# Altium schematic sheet RS232.SchDoc(RS232)
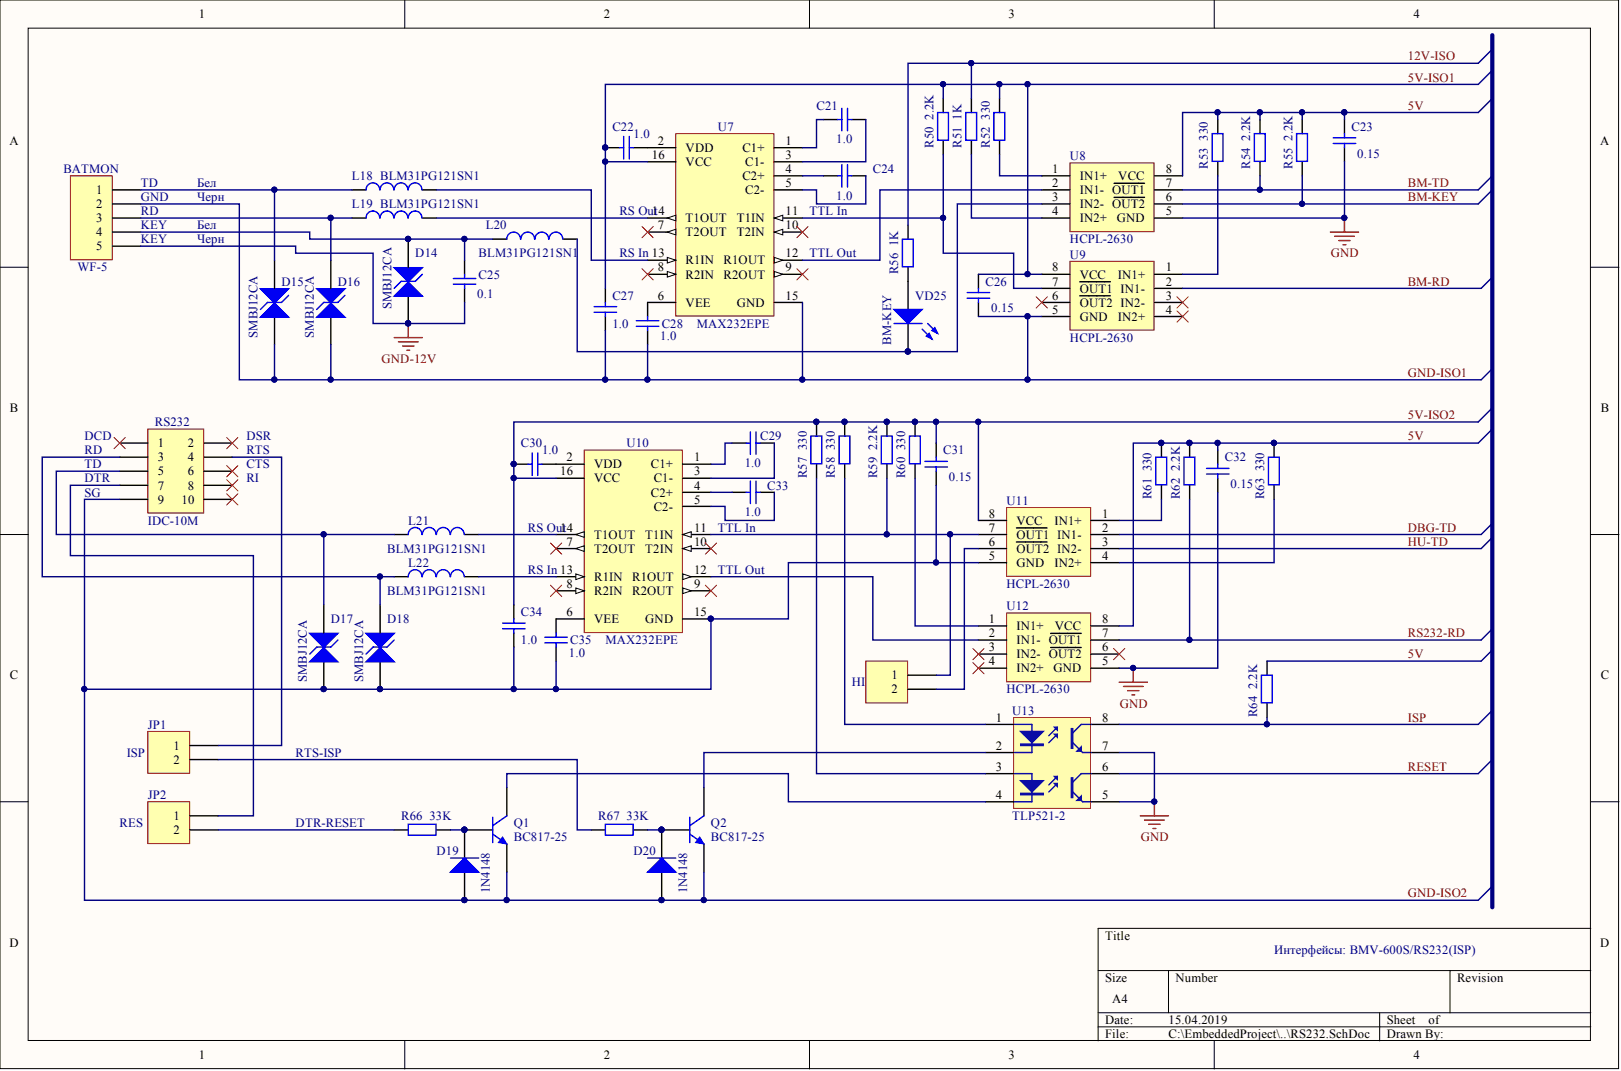
[diagram p0: RS232.SchDoc]

## Parts
BATMON  value WF-5  [WF-5]  (hidden comment: Header, 5-Pin)
C21  value 1.0  [C1206]  (hidden comment: Cap)
C22  value 1.0  [C1206]  (hidden comment: Cap)
C23  value 0.15  [C1206]  (hidden comment: Cap)
C24  value 1.0  [C1206]  (hidden comment: Cap)
C25  value 0.1  [C1206]  (hidden comment: Cap)
C26  value 0.15  [C1206]  (hidden comment: Cap)
C27  value 1.0  [C1206]  (hidden comment: Cap)
C28  value 1.0  [C1206]  (hidden comment: Cap)
C29  value 1.0  [C1206]  (hidden comment: Cap)
C30  value 1.0  [C1206]  (hidden comment: Cap)
C31  value 0.15  [C1206]  (hidden comment: Cap)
C32  value 0.15  [C1206]  (hidden comment: Cap)
C33  value 1.0  [C1206]  (hidden comment: Cap)
C34  value 1.0  [C1206]  (hidden comment: Cap)
C35  value 1.0  [C1206]  (hidden comment: Cap)
D14  SMBJ12CA  [DO-214AA]
D15  SMBJ12CA  [DO-214AA]
D16  SMBJ12CA  [DO-214AA]
D17  SMBJ12CA  [DO-214AA]
D18  SMBJ12CA  [DO-214AA]
D19  value 1N4148  [D1206]  (hidden comment: High Conductance Fast Diode)
D20  value 1N4148  [D1206]  (hidden comment: High Conductance Fast Diode)
H-I  HI  [HDR1X2]
JP1  ISP  [HDR1X2]
JP2  RES  [HDR1X2]
L18  value BLM31PG121SN1  [L1206]  (hidden comment: Ferrite Chip Beads)
L19  value BLM31PG121SN1  [L1206]  (hidden comment: Ferrite Chip Beads)
L20  value BLM31PG121SN1  [L1206]  (hidden comment: Ferrite Chip Beads)
L21  value BLM31PG121SN1  [L1206]  (hidden comment: Ferrite Chip Beads)
L22  value BLM31PG121SN1  [L1206]  (hidden comment: Ferrite Chip Beads)
Q1  value BC817-25  [SOT-23A_N]  (hidden comment: SOT23 NPN Silicon Planar General Purpose Transistor)
Q2  value BC817-25  [SOT-23A_N]  (hidden comment: SOT23 NPN Silicon Planar General Purpose Transistor)
R50  value 2.2K  [1206]  (hidden comment: Res2)
R51  value 1K  [1206]  (hidden comment: Res2)
R52  value 330  [1206]  (hidden comment: Res2)
R53  value 330  [1206]  (hidden comment: Res2)
R54  value 2.2K  [1206]  (hidden comment: Res2)
R55  value 2.2K  [1206]  (hidden comment: Res2)
R56  value 1K  [1206]  (hidden comment: Res2)
R57  value 330  [1206]  (hidden comment: Res2)
R58  value 330  [1206]  (hidden comment: Res2)
R59  value 2.2K  [1206]  (hidden comment: Res2)
R60  value 330  [1206]  (hidden comment: Res2)
R61  value 330  [1206]  (hidden comment: Res2)
R62  value 2.2K  [1206]  (hidden comment: Res2)
R63  value 330  [1206]  (hidden comment: Res2)
R64  value 2.2K  [1206]  (hidden comment: Res2)
R66  value 33K  [1206]  (hidden comment: Res2)
R67  value 33K  [1206]  (hidden comment: Res2)
RS232  value IDC-10M  [IDC10]  (hidden comment: Header, 10-Pin)
U7  value MAX232EPE  [DIP16]  (hidden comment: +5V Powered RS-232 Driver/Receiver)
U8  HCPL-2630  [DIP-8]
U9  HCPL-2630  [DIP-8]
U10  value MAX232EPE  [DIP16]  (hidden comment: +5V Powered RS-232 Driver/Receiver)
U11  HCPL-2630  [DIP-8]
U12  HCPL-2630  [DIP-8]
U13  TLP521-2  [DIP-8 - OPTO]
VD25  BM-KEY  [LED1206 - RED]

## Nets
GND: C23.1 C32.1 U8.5(GND) U12.5(GND) U13.5 U13.7
GND-12V: BATMON.5 C25.2 D14.1
5V: C23.2 C32.2 R53.1 R54.1 R55.1 R61.1 R62.1 R63.1 R64.1 U8.8(VCC) U12.8(VCC)
5V-ISO1: C22.1 C26.2 C27.1 R50.1 R52.1 U7.16(VCC) U9.8(VCC)
5V-ISO2: C30.1 C31.2 C34.1 R57.1 R58.1 R59.1 R60.1 U10.16(VCC) U11.8(VCC)
12V-ISO: R51.1 R56.1
BM-KEY: R55.2 U8.6(OUT2)
BM-RD: U9.2(IN1-)
BM-TD: R54.2 U8.7(OUT1)
DBG-TD: U11.2(IN1-)
GND-ISO1: BATMON.2 C26.1 C27.2 C28.2 D15.1 D16.1 U7.15(GND) U9.5(GND)
GND-ISO2: C31.1 C34.2 C35.2 D17.1 D18.1 D19.1 D20.1 Q1.2 Q2.2 RS232.9 U10.15(GND) U11.5(GND)
HU-TD: U11.3(IN2-)
ISP: R64.2 U13.8
RESET: U13.6
RS232-RD: R62.2 U12.7(OUT1)
NetBATMON_1: BATMON.1 D15.2 L18.1
NetBATMON_3: BATMON.3 D16.2 L19.1
NetBATMON_4: BATMON.4 C25.1 D14.2 L20.1
NetC21_1: C21.1 U7.1(C1+)
NetC21_2: C21.2 U7.3(C1-)
NetC22_2: C22.2 U7.2(VDD)
NetC24_1: C24.1 U7.4(C2+)
NetC24_2: C24.2 U7.5(C2-)
NetC28_1: C28.1 U7.6(VEE)
NetC29_1: C29.1 U10.1(C1+)
NetC29_2: C29.2 U10.3(C1-)
NetC30_2: C30.2 U10.2(VDD)
NetC33_1: C33.1 U10.4(C2+)
NetC33_2: C33.2 U10.5(C2-)
NetC35_1: C35.1 U10.6(VEE)
NetD17_2: D17.2 L21.1 RS232.5
NetD18_2: D18.2 L22.1 RS232.3
NetD19_2: D19.2 Q1.1 R66.1
NetD20_2: D20.2 Q2.1 R67.1
NetH-I_1: H-I.1 R59.2 U10.11(T1IN) U11.7(OUT1)
NetH-I_2: H-I.2 U11.6(OUT2)
NetJP1_1: JP1.1 RS232.4
NetJP1_2: JP1.2 R67.2
NetJP2_1: JP2.1 RS232.7
NetJP2_2: JP2.2 R66.2
NetL18_2: L18.2 U7.13(R1IN)
NetL19_2: L19.2 U7.14(T1OUT)
NetL20_2: L20.2 U8.3(IN2-) VD25.2
NetL21_2: L21.2 U10.14(T1OUT)
NetL22_2: L22.2 U10.13(R1IN)
NetQ1_3: Q1.3 U13.4
NetQ2_3: Q2.3 U13.2
NetR50_2: R50.2 U7.11(T1IN) U9.7(OUT1)
NetR51_2: R51.2 U8.4(IN2+)
NetR52_2: R52.2 U8.1(IN1+)
NetR53_2: R53.2 U9.1(IN1+)
NetR56_2: R56.2 VD25.1
NetR57_2: R57.2 U13.3
NetR58_2: R58.2 U13.1
NetR60_2: R60.2 U12.1(IN1+)
NetR61_2: R61.2 U11.1(IN1+)
NetR63_2: R63.2 U11.4(IN2+)
NetU7_12: U7.12(R1OUT) U8.2(IN1-)
NetU10_12: U10.12(R1OUT) U12.2(IN1-)
N00798:

Not connected: RS232 1 2 6 8 10; U7 7(T2OUT) 8(R2IN) 9(R2OUT) 10(T2IN); U9 3(IN2-) 4(IN2+) 6(OUT2); U10 7(T2OUT) 8(R2IN) 9(R2OUT) 10(T2IN); U12 3(IN2-) 4(IN2+) 6(OUT2)

## Library data of the parts
BATMON = Header 5: Component Kind: Standard; Description: Header, 5-Pin; LatestRevisionDate: 17-Jul-2002; LatestRevisionNote: Re-released for DXP Platform.; Library Name: Miscellaneous Connectors.IntLib; Signal Integrity: Connector; Publisher: Altium Limited
C21-C35 = Cap: Capacitor; Component Kind: Standard; LatestRevisionDate: 17-Jul-2002; LatestRevisionNote: Re-released for DXP Platform.; Library Name: Miscellaneous Devices.IntLib; PackageReference: RAD-0.3; Published: 8-Jun-2000; Signal Integrity: Cap; Simulation: CAP; Publisher: Altium Limited
D14-D18 = SMBJ12CA: Voltage suppressor; Component Kind: Standard; Library Name: Schlib1.SchLib; Value: SMBJ12CA
D19 D20 = 1N4148: Code_JEDEC: DO-204-AH; Component Kind: Standard; ComponentLink1Description: Manufacturer Link; ComponentLink1URL: http://www.fairchildsemi.com/; ComponentLink2Description: Datasheet; ComponentLink2URL: http://www.fairchildsemi.com/ds/1N%2F1N4148.pdf; DatasheetDocument: 1997; Description: High Conductance Fast Diode; LatestRevisionDate: 17-Jul-2002; LatestRevisionNote: Re-released for DXP Platform.; Library Name: FSC Discrete Diode.IntLib; PackageReference: DO-35; Published: 8-Jun-2000; Signal Integrity: 1N4148; Simulation: 1N4148; Publisher: Altium Limited
H-I JP1 JP2 = Header 2: Header, 2-Pin; VALUE: JUMPER; Component Kind: Standard; LatestRevisionDate: 17-Jul-2002; LatestRevisionNote: Re-released for DXP Platform.; Library Name: Miscellaneous Connectors.IntLib; Signal Integrity: Connector; Publisher: Altium Limited
L18-L20 L22 = Inductor: Code_IEC: 1005; Code_JEITA: 0402; Component Kind: Standard; Description: Ferrite Chip Beads; LatestRevisionDate: 29-May-2009; LatestRevisionNote: IPC-7351 Footprint Added.; Library Name: Miscellaneous Devices.IntLib; PackageDescription: Chip Inductor; PackageReference: 0402-A; Published: 8-Jun-2000; Signal Integrity: Inductor; Simulation: INDUCTOR; Publisher: Altium Limited
L21 = Inductor: Code_IEC: 1005; Value: BLM31PG121SN1; Code_JEITA: 0402; Component Kind: Standard; Description: Ferrite Chip Beads; LatestRevisionDate: 29-May-2009; LatestRevisionNote: IPC-7351 Footprint Added.; Library Name: Miscellaneous Devices.IntLib; PackageDescription: Chip Inductor; PackageReference: 0402-A; Published: 8-Jun-2000; Signal Integrity: Inductor; Simulation: INDUCTOR; Publisher: Altium Limited
Q1 Q2 = BC817-25: NPN General Purpose Amplifier; Component Kind: Standard; ComponentLink1Description: Manufacturer Link; ComponentLink1URL: http://www.fairchildsemi.com/; ComponentLink2Description: Discontinuation Notice; ComponentLink2URL: http://www.fairchildsemi.com/obsolete/obs.jsp; DatasheetVersion: 1997; LatestRevisionDate: 20-Nov-2009; LatestRevisionNote: IPC-7351 Footprint Added.; Library Name: FSC Discrete BJT.IntLib; PackageDescription: 3-Pin SOT-23 Package 0.95 mm Pitch, 2.3 mm Lead Span; PackageReference: SOT-23A; PackageVersion: Sep-1998; Published: 8-Jun-2000; Signal Integrity: BC817-25; Simulation: BC817-25; Publisher: Altium Limited
R50-R64 R66 R67 = Res2: Resistor; Component Kind: Standard; LatestRevisionDate: 17-Jul-2002; LatestRevisionNote: Re-released for DXP Platform.; Library Name: Miscellaneous Devices.IntLib; PackageReference: AXIAL-0.4; Published: 8-Jun-2000; Signal Integrity: Res; Simulation: RESISTOR; Publisher: Altium Limited
RS232 = Header 5X2: Component Kind: Standard; Description: Header, 10-Pin; LatestRevisionDate: 17-Jul-2002; LatestRevisionNote: Re-released for DXP Platform.; Library Name: Miscellaneous Connectors.IntLib; Signal Integrity: Connector; Publisher: Altium Limited
U7 U10 = MAX232EPE: Code_JEDEC: MS-001-BB; Component Kind: Standard; ComponentLink1Description: Manufacturer Link; ComponentLink1URL: http://www.maxim-ic.com/; ComponentLink2Description: Datasheet; ComponentLink2URL: http://pdfserv.maxim-ic.com/en/ds/MAX220-MAX249.pdf; DatasheetVersion: Apr-1992; Description: +5V Powered RS-232 Driver/Receiver; LatestRevisionDate: 29-May-2009; LatestRevisionNote: Component Body Added.; Library Name: Maxim Communication Transceiver.IntLib; PackageDescription: DIP, 16-Pin, Row Spacing 7.62 mm, Pitch 2.54 mm; PackageReference: PE16A; PackageVersion: 1997; PCB3D: PE16; Published: 16-Jan-2001; Publisher: Altium Limited
U8 U9 U11 U12 = HCPL-2630: High speed-10 mbit/s logic gate optocouplers dual-channel; Component Kind: Standard; Library Name: Schlib1.SchLib; Value: HCPL-2630
U13 = TLP521-2: 8-Pin Phototransistor Optocoupler; Component Kind: Standard; DatasheetVersion: Nov-2003; LatestRevisionDate: 04-Mar-2005; LatestRevisionNote: Sch pins 3 & 4 Swapped.; Library Name: Schlib1.SchLib; PackageReference: DIP-4; Published: 24-Mar-1999; Value: TLP521-2; Publisher: Altium Limited
VD25 = LED2: SMD LED; value: RED; Component Kind: Standard; LatestRevisionDate: 17-Jul-2002; LatestRevisionNote: Re-released for DXP Platform.; Library Name: Miscellaneous Devices.SchLib; PackageReference: 3.2X1.6X1.1; PackageVersion: Feb-2002; Published: 8-Jun-2000; Simulation: LED2; Publisher: Altium Limited
